# Altium schematic sheet SB_Motor_Driver_Module.SchDoc(SB_Motor_Driver_Module)
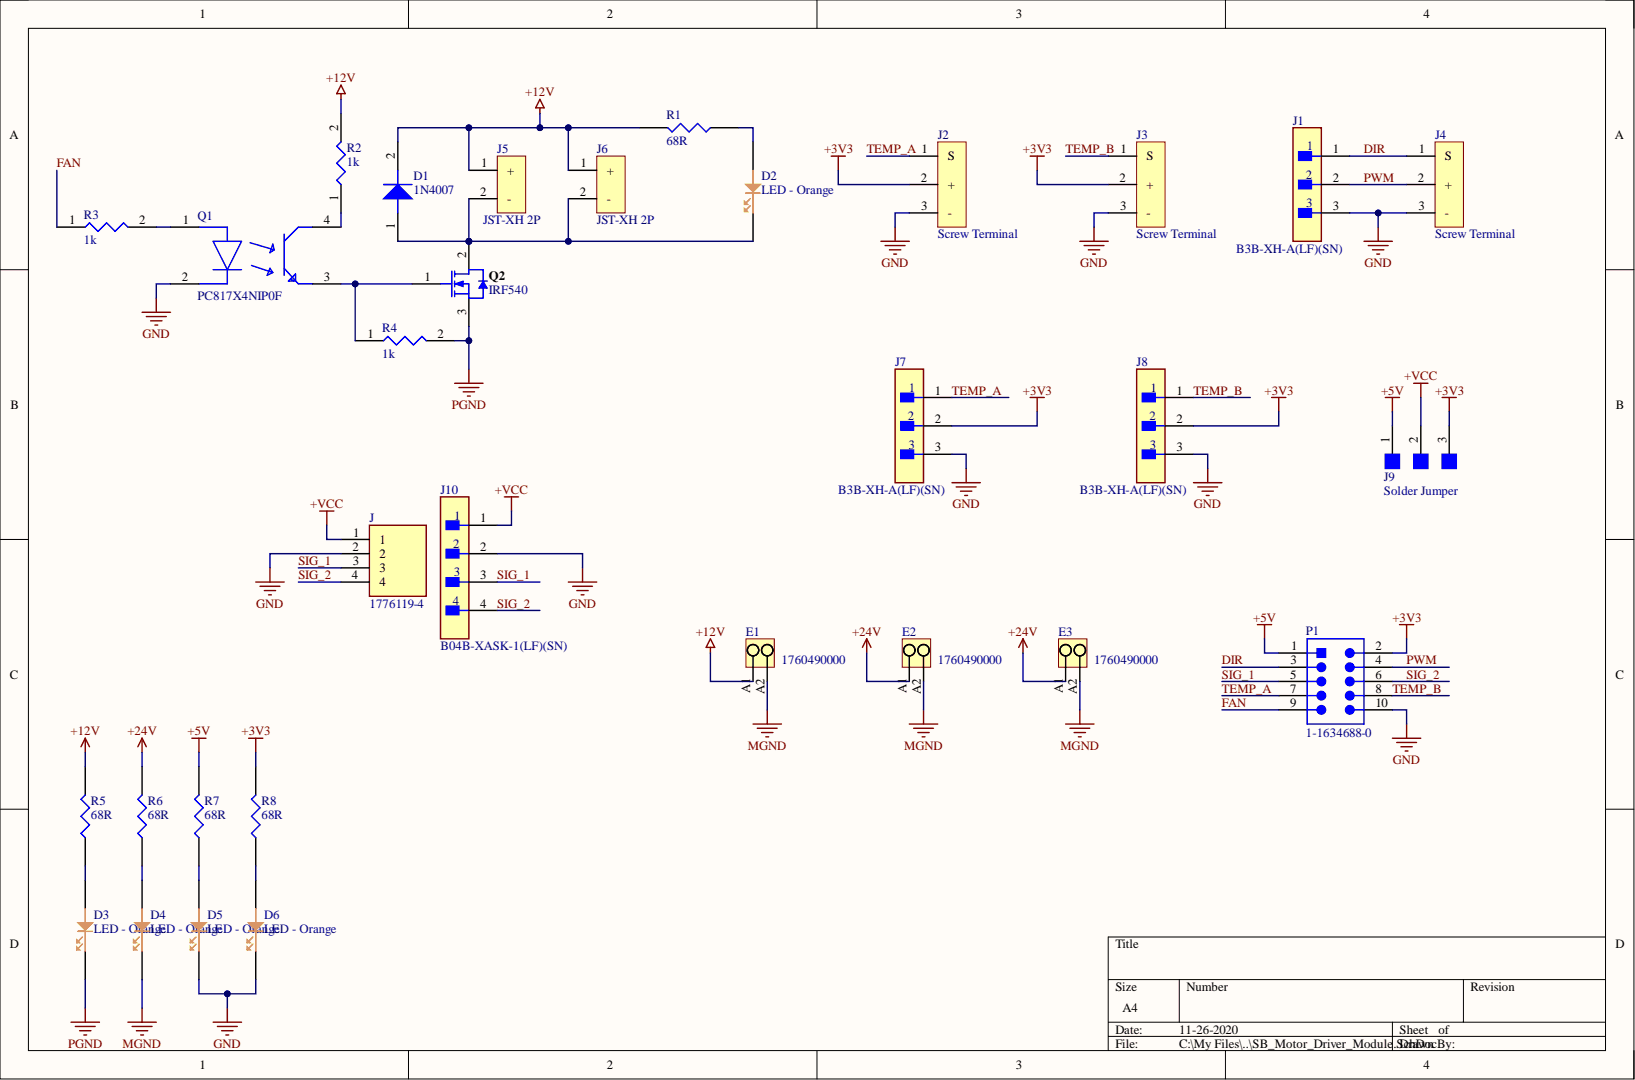
[diagram p0: SB_Motor_Driver_Module.SchDoc]

## Parts
D1  1N4007  [M7 1N4007 SMA]
D2  LED - Orange  [LED0603-Orange]
D3  LED - Orange  [LED0603-Orange]
D4  LED - Orange  Color: Orange  [LED0603-Orange]
D5  LED - Orange  Color: Orange  [LED0603-Orange]
D6  LED - Orange  Color: Orange  [LED0603-Orange]
E1  1760490000  [PM_5_08_90_02]
E2  1760490000  [PM_5_08_90_02]
E3  1760490000  [PM_5_08_90_02]
J  1776119-4  [TE_1776119-4]
J1  B3B-XH-A(LF)(SN)  [FP-B3B-XH-A_LF_SN-MFG]
J2  Screw Terminal  Termination: Screw  [Screw Terminal 3P 5.08MM]
J3  Screw Terminal  Termination: Screw  [Screw Terminal 3P 5.08MM]
J4  Screw Terminal  Termination: Screw  [Screw Terminal 3P 5.08MM]
J5  JST-XH 2P  [JST-XH 2P]
J6  JST-XH 2P  [JST-XH 2P]
J7  B3B-XH-A(LF)(SN)  [FP-B3B-XH-A_LF_SN-MFG]
J8  B3B-XH-A(LF)(SN)  [FP-B3B-XH-A_LF_SN-MFG]
J9  Solder Jumper  [Solder Jumper]
J10  B04B-XASK-1(LF)(SN)  [FP-B04B-XASK-1_LF_SN-MFG]
P1  1-1634688-0  [TECO-1-1634688-0-10_V]
Q1  PC817X4NIP0F  [FP-PC817X4NIP0F-MFG]
Q2  IRF540  [VISH-TO-220AB-3_A]
R1  68R  [68R 0603]
R2  1k  [R0603 1k]
R3  1k  [R0603 1k]
R4  1k  [R0603 1k]
R5  68R  [68R 0603]
R6  68R  [68R 0603]
R7  68R  [68R 0603]
R8  68R  [68R 0603]

## Nets
+3V3: J2.2 J3.2 J7.2 J8.2 J9.3 P1.2 R8.2
+5V: J9.1 P1.1 R7.2
+12V: D1.2 E1.A1 J5.1 J6.1 R1.2 R2.2 R5.2
+24V: E2.A1 E3.A1 R6.2
+VCC: J.1 J9.2 J10.1
GND: D5.1 D6.1 J.2 J1.3 J2.3 J3.3 J4.3 J7.3 J8.3 J10.2 P1.10 Q1.2
MGND: D4.1 E1.A2 E2.A2 E3.A2
PGND: D3.1 Q2.3 R4.2
DIR: J1.1 J4.1 P1.3
FAN: P1.9 R3.1
PWM: J1.2 J4.2 P1.4
SIG_1: J.3 J10.3 P1.5
SIG_2: J.4 J10.4 P1.6
TEMP_A: J2.1 J7.1 P1.7
TEMP_B: J3.1 J8.1 P1.8
NetD1_1: D1.1 D2.1 J5.2 J6.2 Q2.2
NetD2_2: D2.2 R1.1
NetD3_2: D3.2 R5.1
NetD4_2: D4.2 R6.1
NetD5_2: D5.2 R7.1
NetD6_2: D6.2 R8.1
NetQ1_1: Q1.1 R3.2
NetQ1_3: Q1.3 Q2.1 R4.1
NetQ1_4: Q1.4 R2.1

## Library data of the parts
D1 = 1N4007FLTR: 1000V 1A Rectifier; Manufacturer: Sangdest Microelectronics; Component Kind: Standard; Manufacturer Part Number: 1N4007FLTR; Pricing 1: 1=0.10000, 10=0.06600, 100=0.03120, 500=0.01848, 1000=0.01241 (USD); RoHS: No; Stock 1: 2517; Supplier 1: Digi-Key; Supplier Part Number 1: 1655-1N4007FLCT-ND; Library Name: SectionE_BreakOut.SchLib
D2-D6 = LTST-C191KFKT: LED ORANGE CLEAR SMD; Manufacturer: Vishay Lite-On; Dominant Wavelength: 605nm; Forward Current: 30mA; Forward Voltage: 2V; Lens Color: Colorless; Lens Style: Diffused,Colorless,Rectangular; Luminous Intensity: 90mcd; Number of Elements: 1; Power Dissipation: 75mW; Reverse Voltage: 5V; Test Current: 20mA; Viewing Angle: 130°; Wavelength: 611nm; Case Code (Imperial): 0603; Case Code (Metric): 1608; Case/Package: 0603; Category: LEDs; Component Kind: Standard; ComponentLink1Description: https://datasheet.ciiva.com/2109/ltst-c191kfkt-2109968.pdf?src-supplier=Digi-Key; ComponentLink1URL: https://datasheet.ciiva.com/2109/ltst-c191kfkt-2109968.pdf?src-supplier=Digi-Key; ComponentLink2Description: https://datasheet.ciiva.com/12400/100-ltst-c191kfkt-39904-12400811.pdf?src-supplier=Mouser; ComponentLink2URL: https://datasheet.ciiva.com/12400/100-ltst-c191kfkt-39904-12400811.pdf?src-supplier=Mouser; ComponentLink3Description: https://datasheet.ciiva.com/11775/0900766b80dc02b0-11775613.pdf?src-supplier=RSComponents; ComponentLink3URL: https://datasheet.ciiva.com/11775/0900766b80dc02b0-11775613.pdf?src-supplier=RSComponents; ComponentLink4Description: https://datasheet.ciiva.com/15201/getdatasheetpartid-631075-15201391.pdf?src-supplier=Verical; ComponentLink4URL: https://datasheet.ciiva.com/15201/getdatasheetpartid-631075-15201391.pdf?src-supplier=Verical; ComponentLink5Description: https://datasheet.ciiva.com/2109/s-110-ltst-c191kfkt-2109969.pdf?src-supplier=Digi-Key; ComponentLink5URL: https://datasheet.ciiva.com/2109/s-110-ltst-c191kfkt-2109969.pdf?src-supplier=Digi-Key; Depth: 0.8mm; Height: 0.55mm; Illumination Color: Orange; Lead Free: Lead Free; Length: 1.6mm; Lens Transparency: Clear; Manufacturer Part Number: LTST-C191KFKT; Max Operating Temperature: 85°C; Min Operating Temperature: -55°C; Mount: Surface Mount; Number of LEDs: 1; Number of Pins: 2; Package Quantity: 1; Packaging: Tape and Reel; Power Rating: 75mW; Pricing 1: 1=0.24000, 10=0.17900, 100=0.08460, 500=0.05640, 1000=0.04418, 2500=0.04324 (USD); Radiation Hardening: No; RoHS: Yes; Stock 1: 92562; Supplier 1: Digi-Key; Supplier Part Number 1: 160-1445-1-ND; Width: 0.8mm; Library Name: SectionE_BreakOut.SchLib
  D2 D3: Color: Orange
E1-E3 = CMP-1559-01627-1: OMNIMATE Signal - series PM; Manufacturer: Weidmueller; Contact_material: Cu-Leg; Contact_surface: tinned; Insulating_material: Wemid (PA); Mounting_onto_the_PCB: Solder connection; No_of_poles: 2; Pitch_inch: 0,2 inch; Pitch_mm: 5,08 mm; Rated_current_use_group_B_UL_1059: 15 A; Rated_voltage_for_surge_voltage_class_pollution_degree_II_2: 600 V; Rated_voltage_use_group_B_UL_1059: 300 V; Short_text_for_material: PM 5.08/02/90 3.5SN OR BX; Side_termination_characteristic: closed side; Solder_pin_length_mm: 3,5 mm; Solid_max_H05_07_V_U: 2,5 mm²; Solid_min_H05_07_V_U: 0,13 mm²; UL_94_flammability_rating: V-0; Wire_cross_section_AWG_max_UL_1059: AWG 14; Wire_cross_section_AWG_min_UL_1059: AWG 26; Colour: Orange; Component Kind: Standard; ComponentLink1Description: Manufacturer URL; ComponentLink1URL: http://www.weidmueller.com/; ComponentLink2Description: URL Link1; ComponentLink2URL: http://catalog.weidmueller.com/catalog/Start.do?localeId=en&ObjectID=1760490000; Library Name: Altium Content Vault; PackageReference: PM_5_08_90_02; Packaging: Box; PartNumber: 1760490000; Qty: 500 PCS; Revision: V1
J = 1776119-4: 4P TERMI-BLOK,PCB,HIGH TEMP; Centerline_Pitch: 5.08 mm[.2 in]; MF: TE Connectivity; Number_of_Positions: 4; Availability: Unavailable; Component Kind: Standard; Library Name: 1776119-4.IntLib; MP: 1776119-4; Package: None; Price: None; SnapEDA_Link: https://www.snapeda.com/parts/1776119-4/TE%20Connectivity/view-part/2470853/?ref=snap; TE_purchase_URL: https://www.te.com/usa-en/product-1776119-4.html?te_bu=Cor&te_type=disp&te_campaign=seda_glo_cor-seda-global-disp-prtnr-fy19-seda-model-bom-cta_sma-317_1&elqCampaignId=32493
J1 J7 J8 = CMP-17439-000014-1: CONN HEADER VERT 3POS 2.5MM; Manufacturer: JST; Conn Gender: Male; Conn Orientation: Vertical; Current Rating: 3A; Number Of Contacts: 3; Voltage Rating: 250V; Automotive: No; Category: Conn; Component Kind: Standard; ComponentLink2Description: Datasheet; ComponentLink2URL: https://www.jst-mfg.com/product/pdf/eng/eXH.pdf; Contact Material: Tin,Copper; Device Class L1: Connectors; Device Class L2: Headers and Wire Housings; Device Class L3: unset; Digikey Description: CONN HEADER VERT 3POS 2.5MM; Height: 7mm; Lead Free: Yes; Library Name: Altium Content Vault; Manufacturer Part Number: B3B-XH-A(LF)(SN); Number Of Rows: 1; Octopart: https://octopart.com/b3b-xh-a%28lf%29%28sn%29-jst-3990185; Package: 0; Pitch: 2.5mm; RoHS: TRUE; Temperature: -25°C to +85°C; Temperature Range High: +85°C; Temperature Range Low: -25°C
J2-J4 = OSTTC032162: TERM BLK 3P SIDE ENT 5.08MM PCB; Manufacturer: On-Shore Technology; Current: 15A; Number of Contacts: 3; Number of Levels: 1; Pitch: 0.2inch; Plating: Steel; Voltage: 300V; Wire Gauge (Max): 22AWG; Wire Gauge (Min): 14AWG; Category: Terminal Blocks; Color: Blue; Component Kind: Standard; ComponentLink1Description: https://datasheet.ciiva.com/823/osttcxx2162-823568.pdf?src-supplier=Digi-Key; ComponentLink1URL: https://datasheet.ciiva.com/823/osttcxx2162-823568.pdf?src-supplier=Digi-Key; ComponentLink2Description: https://datasheet.ciiva.com/19458/2120655-19458972.pdf?src-supplier=Jameco; ComponentLink2URL: https://datasheet.ciiva.com/19458/2120655-19458972.pdf?src-supplier=Jameco; Contact Material: Brass; Contact Plating: Tin; Flammability Rating: UL94 V-0; Height: 10mm; Housing Color: Blue; Housing Material: Thermoplastic; Lead Free: Lead Free; Length: 15.2mm; Manufacturer Part Number: OSTTC032162; Max Current Rating: 15A; Max Operating Temperature: 105°C; Min Operating Temperature: -30°C; Mount: Through Hole; Number of Positions: 3; Number of Positions Per Level: 3; Number of Rows: 1; Packaging: Bulk; Pricing 1: 1=0.85000, 10=0.53000, 25=0.45400, 50=0.40800, 100=0.39950, 250=0.34000, 500=0.32300, 1000=0.28900, 5000=0.22610 (USD); RoHS: Yes; Stock 1: 3645; Supplier 1: Digi-Key; Supplier Part Number 1: ED2610-ND; Width: 7.6mm; Library Name: SectionE_BreakOut.SchLib
J5 J6 = B2B-XH-A(LF)(SN): JST-XH 2 POS 2.5mm Solder ST Thru-Hole Box; Manufacturer: JST; Connector Type: Male Pin; Contact Resistance: 20mR; Current Rating: 3A; Insulation Resistance: 1GR; Max Voltage Rating (AC): 250V; Number of Contacts: 2; Wire/Cable Gauge: 22AWG; Category: FFC / FPC; Color: Natural; Component Kind: Standard; ComponentLink1Description: https://datasheet.ciiva.com/26894/getdatasheetpartid-640357-26894434.pdf?src-supplier=Verical; ComponentLink1URL: https://datasheet.ciiva.com/26894/getdatasheetpartid-640357-26894434.pdf?src-supplier=Verical; ComponentLink2Description: https://datasheet.ciiva.com/11746/0900766b8082653e-11746979.pdf?src-supplier=RSComponents; ComponentLink2URL: https://datasheet.ciiva.com/11746/0900766b8082653e-11746979.pdf?src-supplier=RSComponents; ComponentLink3Description: https://datasheet.ciiva.com/26894/getdatasheetpartid-385291-26894760.pdf?src-supplier=Verical; ComponentLink3URL: https://datasheet.ciiva.com/26894/getdatasheetpartid-385291-26894760.pdf?src-supplier=Verical; ComponentLink4Description: https://datasheet.ciiva.com/8052/5448-8052024.pdf?src-supplier=Element14; ComponentLink4URL: https://datasheet.ciiva.com/8052/5448-8052024.pdf?src-supplier=Element14; ComponentLink5Description: https://datasheet.ciiva.com/20207/523653-20207167.pdf?src-supplier=Rapid+Electronics; ComponentLink5URL: https://datasheet.ciiva.com/20207/523653-20207167.pdf?src-supplier=Rapid+Electronics; Contact Material: Brass; Contact Plating: Tin,Copper,Lead; Depth: 5.75mm; Fastening Type: Detent; Housing Color: White; Housing Material: Polyamide; Lead Free: Lead Free; Lead Pitch: 2.5mm; Length: 7.4mm; Manufacturer Part Number: B2B-XH-A(LF)(SN); Max Current Rating: 3A; Max Operating Temperature: 85°C; Max Voltage Rating (DC): 250V; Min Operating Temperature: -25°C; Mount: Through Hole; Number of Positions: 2; Number of Rows: 1; Orientation: Straight; Packaging: Bulk; Pitch: 2.5mm; REACH SVHC: No SVHC; RoHS: Yes; Stock 1: 165899; Supplier 1: Element14; Supplier Part Number 1: 1516276; Termination: Solder; Voltage Rating: 250V; Voltage Rating (AC): 250V; Library Name: SectionE_BreakOut.SchLib
J10 = CMP-17439-000018-1: CONN HEADER VERT 4POS 2.5MM; Manufacturer: JST; Conn Gender: Male; Conn Orientation: Straight; Current Rating: 3A; Number Of Contacts: 4; Voltage Rating: 250V; Automotive: No; Category: Conn; Component Kind: Standard; ComponentLink2Description: Datasheet; ComponentLink2URL: http://www.jst-mfg.com/product/pdf/eng/eXA1.pdf; Contact Material: Tin,Copper; Device Class L1: Connectors; Device Class L2: Headers and Wire Housings; Device Class L3: unset; Digikey Description: CONN HEADER VERT 4POS 2.5MM; Height: 8.8mm; Lead Free: Yes; Library Name: Altium Content Vault; Manufacturer Part Number: B04B-XASK-1(LF)(SN); Number Of Rows: 1; Octopart: https://octopart.com/b04b-xask-1%28lf%29%28sn%29-jst-3990149; Package: B04B-XASK-1(LF)(SN); Pitch: 2.5mm; RoHS: TRUE; Temperature: -25°C to +85°C; Temperature Range High: +85°C; Temperature Range Low: -25°C
P1 = CMP-2000-05854-1: Male Header, Pitch 2.54 mm, 2 x 5 Postion, Height 9.15 mm, Tail Length 3.2 mm, -40 to 105 degC, RoHS, Bulk; Manufacturer: TE Connectivity; Contact Termination Type: ThroughHole; No. of Contacts: 10Contacts; No. of Positions: 10; No. of Rows: 2; Package Version: Rev. C2, 02/2011; Pitch: 2.54mm; SVHC: ToBeAdvised; Component Kind: Standard; Contact Material: Brass; Contact Plating: GoldPlatedContacts; Datasheet URL: https://datasheet.ciiva.com/6831/eng-cd-1634688-c2-6831201.pdf; Datasheet Version: Rev. C2, 02/2011; Gender: Header; Library Name: Altium Content Vault; Manufacturer URL: http://www.te.com/; Mounting Technology: ThroughHole; Orientation: Straight; Package Description: 10-Pin Connector, Body 20.35 x 8.7 mm, Pitch 2.54 mm; Package Reference: 1-1634688-0; Package URL: https://datasheet.ciiva.com/6831/eng-cd-1634688-c2-6831201.pdf; PartNumber: 1-1634688-0; Pitch Spacing: 2.54mm; Product Range: -
Q1 = CMP-65434-000001-1: OPTOISOLATOR 5KV TRANS 4SMD; Manufacturer: Sharp; Collector Emitter Voltage: 80V; Emiiter Collector Voltage: 6V; Forward Current: 20mA; Forward Voltage: 1.2V; Isolation Voltage: 5kVrms; Max Current Transfer Ratio: 600%; Min Current Transfer Ratio: 50%; Power Dissipation: 200mW; Standoff Height: 0.1mm; Automotive: No; Category: Trans; Component Kind: Standard; ComponentLink2Description: Datasheet; ComponentLink2URL: https://www.sharpsde.com/fileadmin/products/Optoelectronics/Isolation%20Devices/Specs%20Photocoupler/PC817XxNIP0F_17Jun08_Spec_ED-04P129A.pdf; Device Class L1: Optoelectronics; Device Class L2: Optocouplers; Device Class L3: unset; Digikey Description: OPTOISOLATOR 5KV TRANS 4SMD; Height: 4.6mm; Lead Free: Yes; Library Name: Altium Content Vault; Manufacturer Part Number: PC817X4NIP0F; Mouser Description: Transistor Output Optocouplers Photocoupler 300-600% CRT; Octopart: https://octopart.com/pc817x4nip0f-sharp-20650453; Package: PC817X4NIP0F; Reverse Voltage: 6V; RoHS: TRUE; Temperature: -30°C to +100°C; Temperature Range High: +100°C; Temperature Range Low: -30°C
Q2 = CMP-2000-06461-1: Power MOSFET, 100 VDS, -55 to 175 degC, 3-Pin TO-220AB, RoHS, Tube; Manufacturer: Vishay; Brand: VishaySemiconductors; Channel Mode: Enhancement; Configuration: Single; Fall Time: 43ns; Id - Continuous Drain Current: 28A; Number of Channels: 1Channel; Pd - Power Dissipation: 150W; Rds On - Drain-Source Resistance: 77mOhms; Rise Time: 44ns; Transistor Polarity: N-Channel; Transistor Type: 1N-Channel; Typical Turn-Off Delay Time: 53ns; Typical Turn-On Delay Time: 11ns; Unit Weight: 0.211644oz; Vds - Drain-Source Breakdown Voltage: 100V; Vgs - Gate-Source Voltage: 20V; &lt;PCN&gt;: http://www.mouser.com/PCN/Vishay_SIL_0182015.pdf; Component Kind: Standard; Datasheet URL: http://www.vishay.com/docs/91021/91021.pdf; Datasheet Version: Rev. B, 03/2011; Factory Pack Quantity: 50; Height: 9.01mm; Length: 10.51mm; Library Name: Altium Content Vault; Manufacturer URL: http://www.vishay.com/; Maximum Operating Temperature: +175C; Minimum Operating Temperature: -55C; Mounting Style: ThroughHole; Mounting Technology: ThroughHole; Package / Case: TO-220-3; Package Description: 3-Pin Flange Mount, Body 10.275 x 4.45 mm, Pitch 2.54 mm; Package Reference: TO-220AB-3; Package URL: http://www.vishay.com/docs/71195/to220ab.pdf; Packaging: Tube; Product Category: MOSFET; RoHS: NoRoHSVersionAvailable; Technology: Si; Width: 4.7mm
R1 R5-R8 = CRGP0603F68R: CRGP 0603 68R 1%; Manufacturer: TE Connectivity; Max Power Dissipation: 250mW; Temperature Coefficient: 200ppm/°C; Case Code (Imperial): 0603; Case Code (Metric): 1608; Case/Package: 0603; Category: Chip SMD Resistors; Component Kind: Standard; ComponentLink1Description: https://www.te.com/commerce/DocumentDelivery/DDEController?Action=srchrtrv&amp;DocNm=9-1773463-9&amp;DocType=DS&amp;DocLang=English&src-supplier=Digi-Key; ComponentLink1URL: https://www.te.com/commerce/DocumentDelivery/DDEController?Action=srchrtrv&amp;DocNm=9-1773463-9&amp;DocType=DS&amp;DocLang=English&src-supplier=Digi-Key; Manufacturer Part Number: CRGP0603F68R; Max Operating Temperature: 155°C; Min Operating Temperature: -55°C; Number of Terminations: 2; Packaging: Tape and Reel; Pricing 1: 1=0.14000, 10=0.11400, 100=0.04470, 1000=0.01870, 2500=0.01714 (USD); Resistance: 68R; Resistor Type: Thick Film; RoHS: No; Stock 1: 49308; Supplier 1: Digi-Key; Supplier Part Number 1: A130402CT-ND; Tolerance: 1%; Library Name: SectionE_BreakOut.SchLib
R2-R4 = CRGCQ0603F1K0: 0603 1k Ohm 1% 1/10W; Manufacturer: TE Connectivity; Max Power Dissipation: 100mW; Resistance: 1kR; Temperature Coefficient: 100ppm/°C; Case Code (Imperial): 0603; Case Code (Metric): 1608; Case/Package: 0603; Category: Chip SMD Resistors; Component Kind: Standard; ComponentLink1Description: https://www.te.com/commerce/DocumentDelivery/DDEController?Action=srchrtrv&DocNm=1773204-3&DocType=DS&DocLang=English&src-supplier=Digi-Key; ComponentLink1URL: https://www.te.com/commerce/DocumentDelivery/DDEController?Action=srchrtrv&DocNm=1773204-3&DocType=DS&DocLang=English&src-supplier=Digi-Key; ComponentLink2Description: https://4donline.ihs.com/images/VipMasterIC/IC/TYEL/TYEL-S-A0004929575/TYEL-S-A0004929575-1.pdf?hkey=52A5661711E402568146F3353EA87419&src-supplier=Farnell; ComponentLink2URL: https://4donline.ihs.com/images/VipMasterIC/IC/TYEL/TYEL-S-A0004929575/TYEL-S-A0004929575-1.pdf?hkey=52A5661711E402568146F3353EA87419&src-supplier=Farnell; ComponentLink3Description: https://www.te.com/commerce/DocumentDelivery/DDEController?Action=srchrtrv&amp;DocNm=1773204-3&amp;DocType=DS&amp;DocLang=English&src-supplier=Digi-Key; ComponentLink3URL: https://www.te.com/commerce/DocumentDelivery/DDEController?Action=srchrtrv&amp;DocNm=1773204-3&amp;DocType=DS&amp;DocLang=English&src-supplier=Digi-Key; ComponentLink4Description: https://4donline.ihs.com/images/VipMasterIC/IC/TYEL/TYEL-S-A0004929575/TYEL-S-A0004929575-1.pdf?hkey=52A5661711E402568146F3353EA87419&src-supplier=Element14; ComponentLink4URL: https://4donline.ihs.com/images/VipMasterIC/IC/TYEL/TYEL-S-A0004929575/TYEL-S-A0004929575-1.pdf?hkey=52A5661711E402568146F3353EA87419&src-supplier=Element14; ComponentLink5Description: https://4donline.ihs.com/images/VipMasterIC/IC/TYEL/TYEL-S-A0004929575/TYEL-S-A0004929575-1.pdf?hkey=52A5661711E402568146F3353EA87419&src-supplier=Newark; ComponentLink5URL: https://4donline.ihs.com/images/VipMasterIC/IC/TYEL/TYEL-S-A0004929575/TYEL-S-A0004929575-1.pdf?hkey=52A5661711E402568146F3353EA87419&src-supplier=Newark; Features: Moisture Resistant,Automotive AEC-Q200; Manufacturer Part Number: CRGCQ0603F1K0; Max Operating Temperature: 155°C; Min Operating Temperature: -55°C; Number of Terminations: 2; Packaging: Cut Tape; Pricing 1: 1=0.10000, 10=0.02700, 100=0.01090, 1000=0.00490, 2500=0.00426 (USD); Resistor Type: Thick Film; RoHS: No; Stock 1: 37973; Supplier 1: Digi-Key; Supplier Part Number 1: A129688CT-ND; Tolerance: 1%; Library Name: SectionE_BreakOut.SchLib
J9 = Solder Jumper: Component Kind: Standard; Library Name: SectionE_BreakOut.SchLib
